# Altium schematic sheet JW302-01-V01_A0.SchDoc
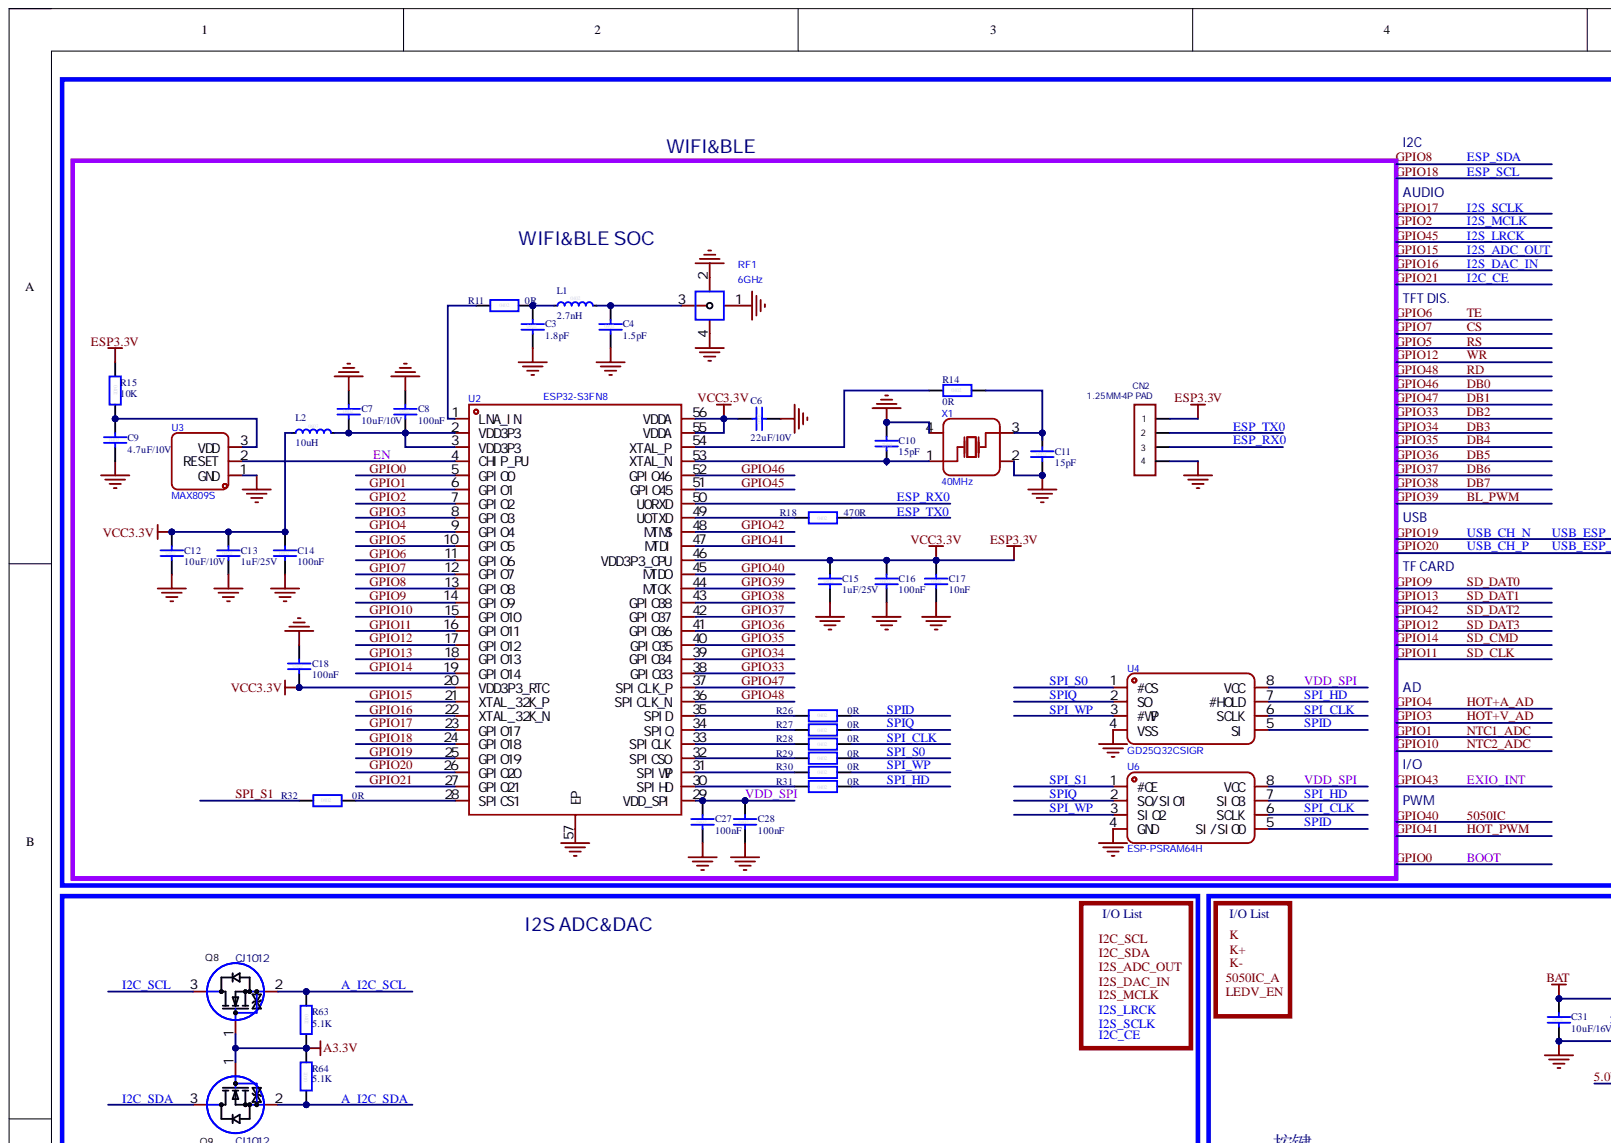
[diagram p0: JW302-01-V01_A0.SchDoc, top left]
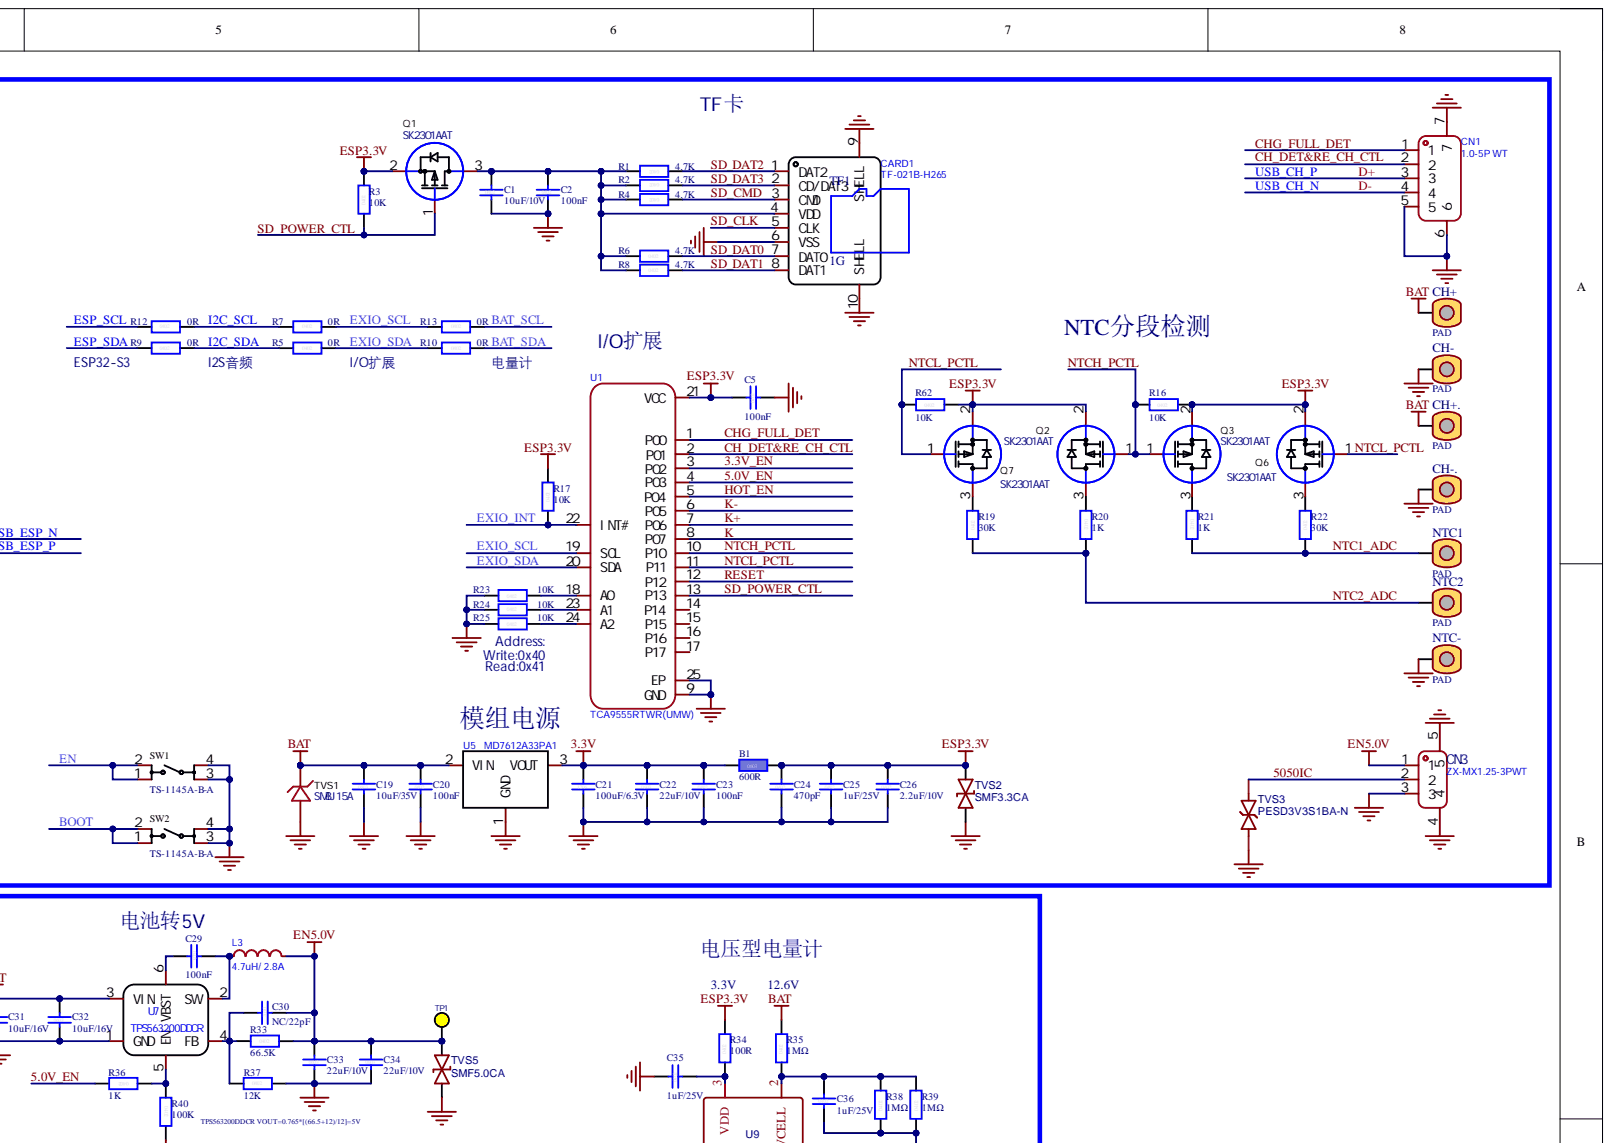
[diagram p1: JW302-01-V01_A0.SchDoc, top right]
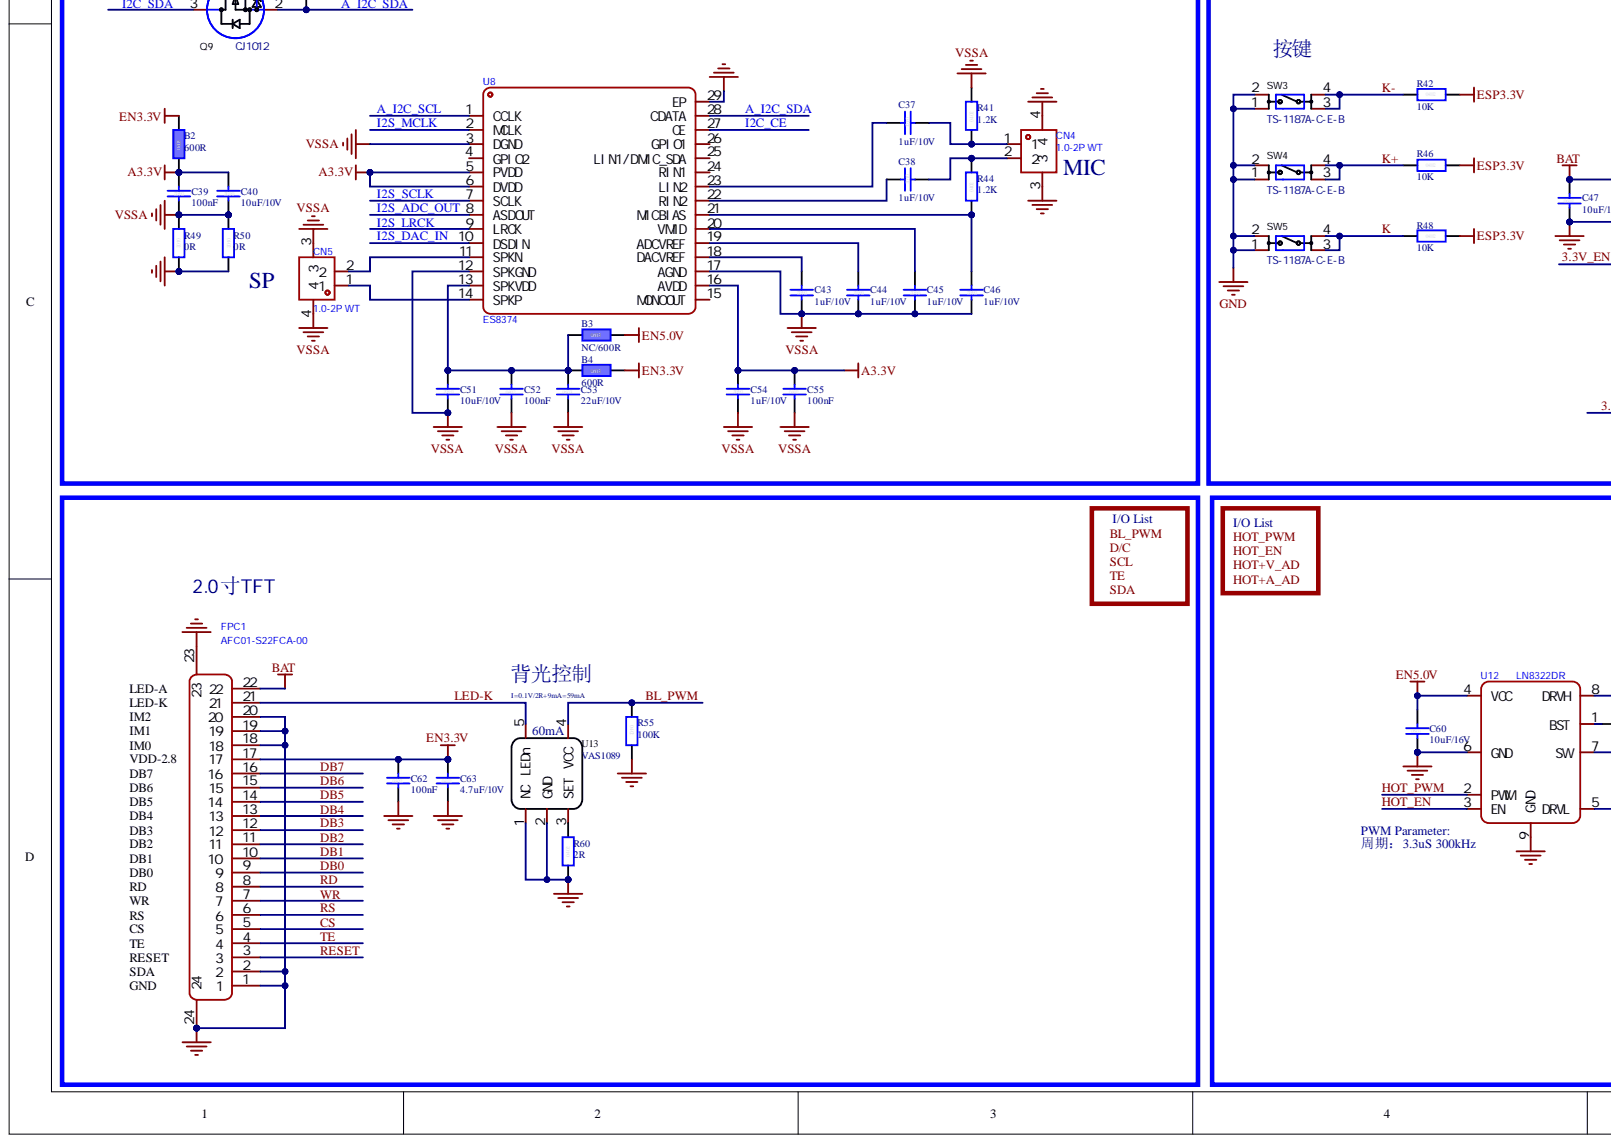
[diagram p2: JW302-01-V01_A0.SchDoc, bottom left]
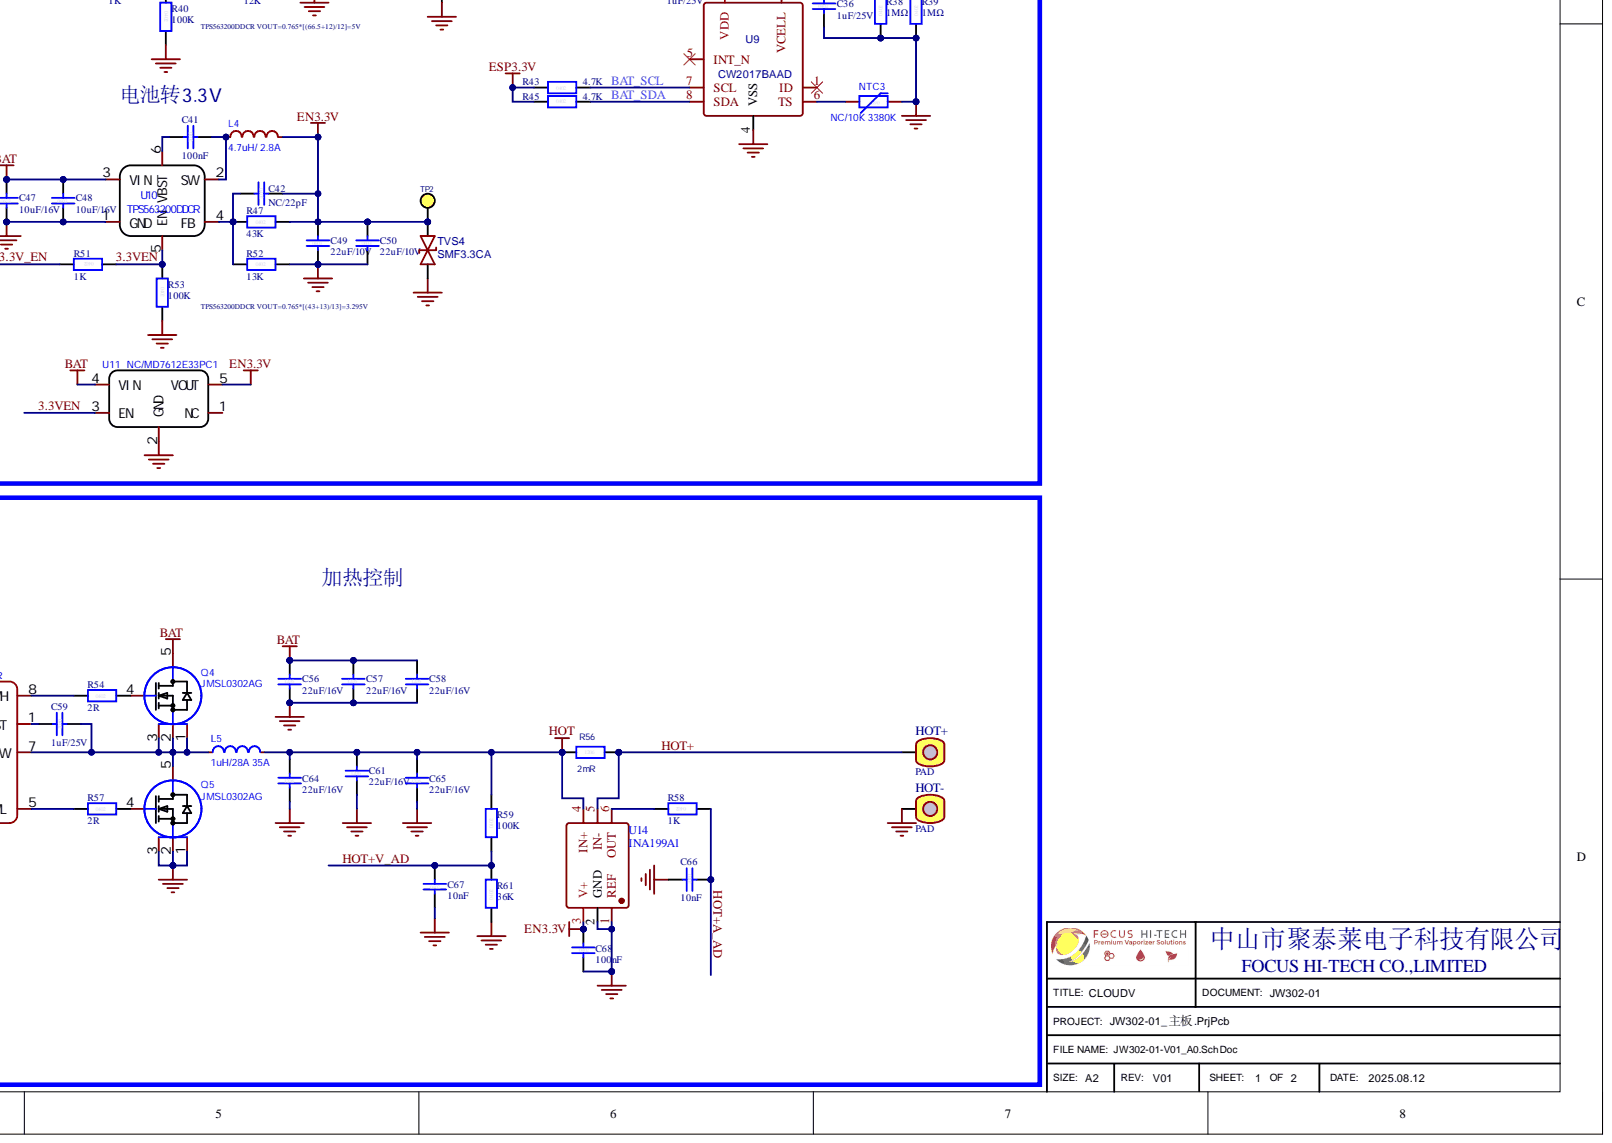
[diagram p3: JW302-01-V01_A0.SchDoc, bottom right]

## Parts
B1  600R  [R 0603_L]
B2  600R  [R 0603_L]
B3  NC/600R  [R 0603_L]
B4  600R  [R 0603_L]
C1  10uF/10V  [C 0402_L]
C2  100nF  [C 0402_L]
C3  1.8pF  [C 0402_L]
C4  1.5pF  [C 0402_L]
C5  100nF  [C 0402_L]
C6  22uF/10V  [C 0603_L]
C7  10uF/10V  [C 0402_L]
C8  100nF  [C 0402_L]
C9  4.7uF/10V  [C 0402_L]
C10  15pF  [C 0402_L]
C11  15pF  [C 0402_L]
C12  10uF/10V  [C 0402_L]
C13  1uF/25V  [C 0402_L]
C14  100nF  [C 0402_L]
C15  1uF/25V  [C 0402_L]
C16  100nF  [C 0402_L]
C17  10nF  [C 0402_L]
C18  100nF  [C 0402_L]
C19  10uF/35V  [C 0603_L]
C20  100nF  [C 0402_L]
C21  100uF/6.3V  [C 1206_L]
C22  22uF/10V  [C 0603_L]
C23  100nF  [C 0402_L]
C24  470pF  [C 0402_L]
C25  1uF/25V  [C 0402_L]
C26  2.2uF/10V  [C 0402_L]
C27  100nF  [C 0402_L]
C28  100nF  [C 0402_L]
C29  100nF  [C 0402_L]
C30  NC/22pF  [C 0402_L]
C31  10uF/16V  [C 0603_L]
C32  10uF/16V  [C 0603_L]
C33  22uF/10V  [C 0603_L]
C34  22uF/10V  [C 0603_L]
C35  1uF/25V  [C 0402_L]
C36  1uF/25V  [C 0402_L]
C37  1uF/10V  [C 0402_L]
C38  1uF/10V  [C 0402_L]
C39  100nF  [C 0402_L]
C40  10uF/10V  [C 0402_L]
C41  100nF  [C 0402_L]
C42  NC/22pF  [C 0402_L]
C43  1uF/10V  [C 0402_L]
C44  1uF/10V  [C 0402_L]
C45  1uF/10V  [C 0402_L]
C46  1uF/10V  [C 0402_L]
C47  10uF/16V  [C 0603_L]
C48  10uF/16V  [C 0603_L]
C49  22uF/10V  [C 0603_L]
C50  22uF/10V  [C 0603_L]
C51  10uF/10V  [C 0402_L]
C52  100nF  [C 0402_L]
C53  22uF/10V  [C 0603_L]
C54  1uF/10V  [C 0402_L]
C55  100nF  [C 0402_L]
C56  22uF/16V  [C 1206_L]
C57  22uF/16V  [C 1206_L]
C58  22uF/16V  [C 1206_L]
C59  1uF/25V  [C 0402_L]
C60  10uF/16V  [C 0603_L]
C61  22uF/16V  [C 1206_L]
C62  100nF  [C 0402_L]
C63  4.7uF/10V  [C 0402_L]
C64  22uF/16V  [C 1206_L]
C65  22uF/16V  [C 1206_L]
C66  10nF  [C 0402_L]
C67  10nF  [C 0402_L]
C68  100nF  [C 0402_L]
CARD1  TF-021B-H265  [TF-SMD_TF-021B-H265]
CH+  PAD  [接线焊盘2.0*2.0/1.0mm]
CH+.  PAD  [接线焊盘2.0*2.0/1.0mm]
CH-  PAD  [接线焊盘2.0*2.0/1.0mm]
CH-.  PAD  [接线焊盘2.0*2.0/1.0mm]
CN1  1.0-5P WT  [CONN-SMD_5P-P1.00_SHOU-HAN_1.0-5P-WT]
CN2  1.25MM-4P PAD  [1.25MM-4P PAD]
CN3  ZX-MX1.25-3PWT  [CONN-SMD_3P-P1.25_ZX-MX1.25-3PWT]
CN4  1.0-2P WT  [CONN-SMD_2P-P1.00_SHOU-HAN_1.0-2P-WT]
CN5  1.0-2P WT  [CONN-SMD_2P-P1.00_SHOU-HAN_1.0-2P-WT]
FPC1  AFC01-S22FCA-00  [FPC-SMD_P0.5-22P_FGS-XJ-H2.0]
HOT+  PAD  [接线焊盘2.0*2.0/1.0mm]
HOT-  PAD  [接线焊盘2.0*2.0/1.0mm]
L1  2.7nH  [R 0402_L]
L2  10uH  [R 0603_L]
L3  4.7uH/ 2.8A  Rated Current: 2.8A  [IND-SMD_L4.4-W4.2]
L4  4.7uH/ 2.8A  Rated Current: 2.8A  [IND-SMD_L4.4-W4.2]
L5  1uH/28A 35A  Saturation Current (Isat): 35A  [IND-SMD_L12.6-W13.5]
NTC1  PAD  [接线焊盘2.0*2.0/1.0mm]
NTC2  PAD  [接线焊盘2.0*2.0/1.0mm]
NTC3  NC/10K 3380K  B Constant (25℃/50℃): 3380K  Supplier Footprint: 0603  [R0603]
NTC-  PAD  [接线焊盘2.0*2.0/1.0mm]
Q1  SK2301AAT  [SOT-523-3_L1.6-W0.8-P1.00-LS1.6-BR]
Q2  SK2301AAT  [SOT-523-3_L1.6-W0.8-P1.00-LS1.6-BR]
Q3  SK2301AAT  [SOT-523-3_L1.6-W0.8-P1.00-LS1.6-BR]
Q4  JMSL0302AG  [DFN-5_L6.1-W5.1-P1.27-BL]
Q5  JMSL0302AG  [DFN-5_L6.1-W5.1-P1.27-BL]
Q6  SK2301AAT  [SOT-523-3_L1.6-W0.8-P1.00-LS1.6-BR]
Q7  SK2301AAT  [SOT-523-3_L1.6-W0.8-P1.00-LS1.6-BR]
Q8  CJ1012  [SOT-523-3_L1.6-W0.8-P1.00-LS1.6-BR]
Q9  CJ1012  [SOT-523-3_L1.6-W0.8-P1.00-LS1.6-BR]
R1  4.7K  [R 0402_L]
R2  4.7K  [R 0402_L]
R3  10K  [R 0402_L]
R4  4.7K  [R 0402_L]
R5  0R  [R 0402_L]
R6  4.7K  [R 0402_L]
R7  0R  [R 0402_L]
R8  4.7K  [R 0402_L]
R9  0R  [R 0402_L]
R10  0R  [R 0402_L]
R11  0R  [R 0402_L]
R12  0R  [R 0402_L]
R13  0R  [R 0402_L]
R14  0R  [R 0402_L]
R15  10K  [R 0402_L]
R16  10K  [R 0402_L]
R17  10K  [R 0402_L]
R18  470R  [R 0402_L]
R19  30K  [R 0402_L]
R20  1K  [R 0402_L]
R21  1K  [R 0402_L]
R22  30K  [R 0402_L]
R23  10K  [R 0402_L]
R24  10K  [R 0402_L]
R25  10K  [R 0402_L]
R26  0R  [R 0402_L]
R27  0R  [R 0402_L]
R28  0R  [R 0402_L]
R29  0R  [R 0402_L]
R30  0R  [R 0402_L]
R31  0R  [R 0402_L]
R32  0R  [R 0402_L]
R33  66.5K  [R 0402_L]
R34  100R  [R 0402_L]
R35  1MΩ  [R 0402_L]
R36  1K  [R 0402_L]
R37  12K  [R 0402_L]
R38  1MΩ  [R 0402_L]
R39  1MΩ  [R 0402_L]
R40  100K  [R 0402_L]
R41  1.2K  [R 0402_L]
R42  10K  [R 0402_L]
R43  4.7K  [R 0402_L]
R44  1.2K  [R 0402_L]
R45  4.7K  [R 0402_L]
R46  10K  [R 0402_L]
R47  43K  [R 0402_L]
R48  10K  [R 0402_L]
R49  0R  [R 0402_L]
R50  0R  [R 0402_L]
R51  1K  [R 0402_L]
R52  13K  [R 0402_L]
R53  100K  [R 0402_L]
R54  2R  [R 0402_L]
R55  100K  [R 0402_L]
R56  2mR  [R 1206_L]
R57  2R  [R 0402_L]
R58  1K  [R 0402_L]
R59  100K  [R 0402_L]
R60  2R  [R 0402_L]
R61  36K  [R 0402_L]
R62  10K  [R 0402_L]
R63  5.1K  [R 0402_L]
R64  5.1K  [R 0402_L]
RF1  6GHz  [IPEX-SMD_4P-L1.7-W1.7-LS2.0-L-4]
SW1  TS-1145A-B-A  [SW-SMD_4P-L4.2-W3.2-P2.15-LS5.2]
SW2  TS-1145A-B-A  [SW-SMD_4P-L4.2-W3.2-P2.15-LS5.2]
SW3  TS-1187A-C-E-B  [SW-SMD_4P-L5.1-W5.1-P3.70-LS6.5-TL-2]
SW4  TS-1187A-C-E-B  [SW-SMD_4P-L5.1-W5.1-P3.70-LS6.5-TL-2]
SW5  TS-1187A-C-E-B  [SW-SMD_4P-L5.1-W5.1-P3.70-LS6.5-TL-2]
TF1  1G  [TFCard]
TP1  TP  [TP]
TP2  TP  [TP]
TVS1  SMBJ15A  [SMB_L4.6-W3.6-LS5.3-RD]
TVS2  SMF3.3CA  [SOD-123_L2.8-W1.8-LS3.7-BI]
TVS3  PESD3V3S1BA-N  [SOD-523_L1.2-W0.8-LS1.6-BI]
TVS4  SMF3.3CA  [SOD-123_L2.8-W1.8-LS3.7-BI]
TVS5  SMF5.0CA  [SOD-123_L2.8-W1.8-LS3.7-BI]
U1  TCA9555RTWR(UMW)  [HVQFN-24_L4.0-W4.0-P0.50-BL-EP2.6]
U2  ESP32-S3FN8  [QFN-56_L7.0-W7.0-P0.40-TL-EP4.0]
U3  MAX809S  [SOT-23-3_L2.9-W1.3-P1.90-LS2.4-BR]
U4  GD25Q32CSIGR  [SOIC-8_L5.3-W5.3-P1.27-LS8.0-BL]
U5  MD7612A33PA1  [SOT-89-3_L4.5-W2.5-P1.50-LS4.2-BR]
U6  ESP-PSRAM64H  [SOP-8_L4.9-W3.9-P1.27-LS6.0-BL]
U7  TPS563200DDCR  [SOT-23-6_L2.9-W1.6-P0.95-LS2.8-BR]
U8  ES8374  [QFN-28_L4.0-W4.0-P0.45-BL-EP]
U9  CW2017BAAD  [WDFN-8_L2.0-W2.0-P0.50-BL]
U10  TPS563200DDCR  [SOT-23-6_L2.9-W1.6-P0.95-LS2.8-BR]
U11  NC/MD7612E33PC1  [SOT-89-5_L4.5-W2.5-P1.50-LS4.5-BR]
U12  LN8322DR  [WDFN-8_L2.0-W2.0-P0.50-BL-EP]
U13  VAS1089  [SOT23-5L]
U14  INA199A1  [SC-70-6_L2.2-W1.3-P0.65-LS2.1-BR]
X1  40MHz  External load capacitor: 15pF  [CRYSTAL-SMD_4P-L3.2-W2.5-BL-1]

## Nets
3.3V: B1.1 C21.2 C22.2 C23.2 U5.3
A3.3V: B2.1 C39.1 C40.1 C54.1 C55.2 Q8.1 Q9.1 R63.2 R64.2 U8.5(PVDD) U8.6(DVDD) U8.16(AVDD)
BAT: C19.2 C20.2 C31.2 C32.2 C47.2 C48.2 C56.2 C57.2 C58.2 CH+.1 CH+..1 FPC1.22 Q4.5 R35.2 TVS1.1 U5.2 U7.3(VIN) U10.3(VIN) U11.4(VIN)
EN3.3V: B2.2 B4.2 C42.2 C49.2 C50.2 C62.2 C63.2 C68.2 FPC1.17 L4.2 R47.2 TP2.1 TVS4.2 U11.5(VOUT) U14.3(V+)
EN5.0V: B3.2 C30.2 C33.2 C34.2 C60.2 CN3.1 L3.2 R33.2 TP1.1 TVS5.2 U12.4(VCC)
ESP3.3V: B1.2 C5.2 C6.2 C12.2 C13.2 C14.2 C15.2 C16.2 C17.2 C18.1 C24.2 C25.2 C26.2 CN2.1 L2.1 Q1.2 Q2.2 Q3.2 Q6.2 Q7.2 R3.2 R15.1 R16.2 R17.2 R34.2 R42.2 R43.1 R45.1 R46.2 R48.2 R62.2 TVS2.2 U1.21(VCC) U2.20(VDD3P3_RTC) U2.46(VDD3P3_CPU) U2.55(VDDA) U2.56(VDDA)
GND: C1.1 C2.1 C3.1 C4.1 C5.1 C6.1 C7.2 C8.2 C9.1 C10.1 C11.1 C12.1 C13.1 C14.1 C15.1 C16.1 C17.1 C18.2 C19.1 C20.1 C21.1 C22.1 C23.1 C24.1 C25.1 C26.1 C27.1 C28.1 C31.1 C32.1 C33.1 C34.1 C35.2 C36.1 C47.1 C48.1 C49.1 C50.1 C56.1 C57.1 C58.1 C60.1 C61.1 C62.1 C63.1 C64.1 C65.1 C66.1 C67.1 C68.1 CARD1.6(VSS) CARD1.9(SHELL) CARD1.10(SHELL) CH-.1 CH-..1 CN1.5 CN1.6 CN1.7 CN2.4 CN3.3 CN3.4 CN3.5 CN4.3 CN4.4 FPC1.1 FPC1.2 FPC1.18 FPC1.19 FPC1.20 FPC1.23 FPC1.24 HOT-.1 NTC3.1 NTC-.1 Q5.1 Q5.2 Q5.3 R23.1 R24.1 R25.1 R37.2 R38.1 R39.1 R40.2 R49.2 R50.2 R52.2 R53.2 R55.2 R60.1 R61.1 RF1.1 RF1.2 RF1.4 SW1.3 SW1.4 SW2.3 SW2.4 SW3.1 SW3.2 SW4.1 SW4.2 SW5.1 SW5.2 TVS1.2 TVS2.1 TVS3.1 TVS4.1 TVS5.1 U1.9(GND) U1.25(EP) U2.57(EP) U3.1 U4.4(VSS) U5.1 U6.4(GND) U7.1(GND) U8.29(EP) U9.4(VSS) U10.1(GND) U11.2(GND) U12.6(GND) U12.9(GND) U13.1(NC) U13.2(GND) U14.1(REF) U14.2(GND) X1.2 X1.4
HOT: C61.2 C64.2 C65.2 L5.2 R56.1 R59.2 U14.4(IN+)
VSSA: C39.2 C40.2 C43.2 C44.2 C45.2 C46.2 C51.2 C52.1 C53.1 C54.2 C55.1 CN5.3 CN5.4 R41.2 R49.1 R50.1 U8.3(DGND) U8.12(SPKGND) U8.17(AGND)
3.3V_EN: R51.2 U1.3(P02)
3.3VEN: R51.1 R53.1 U10.5(EN) U11.3(EN)
5.0V_EN: R36.2 U1.4(P03)
5050IC: CN3.2 TVS3.2 U2.45(MTDO)
A_I2C_SCL: Q8.2 R63.1 U8.1(CCLK)
A_I2C_SDA: Q9.2 R64.1 U8.28(CDATA)
BAT_SCL: R13.1 R43.2 U9.7(SCL)
BAT_SDA: R10.1 R45.2 U9.8(SDA)
BL_PWM: R55.1 U2.44(MTCK) U13.4(VCC)
BOOT: SW2.1 SW2.2 U2.5(GPIO0)
CH_DET&RE_CH_CTL: CN1.2 U1.2(P01)
CHG_FULL_DET: CN1.1 U1.1(P00)
CS: FPC1.5 U2.12(GPIO7)
D+: CN1.3 U2.26(GPIO20)
D-: CN1.4 U2.25(GPIO19)
DB0: FPC1.9 U2.52(GPIO46)
DB1: FPC1.10 U2.37(SPICLK_P)
DB2: FPC1.11 U2.38(GPIO33)
DB3: FPC1.12 U2.39(GPIO34)
DB4: FPC1.13 U2.40(GPIO35)
DB5: FPC1.14 U2.41(GPIO36)
DB6: FPC1.15 U2.42(GPIO37)
DB7: FPC1.16 U2.43(GPIO38)
EN: SW1.1 SW1.2 U2.4(CHIP_PU) U3.2
ESP_RX0: CN2.3 U2.50(U0RXD)
ESP_SCL: R12.1 U2.24(GPIO18)
ESP_SDA: R9.1 U2.13(GPIO8)
ESP_TX0: CN2.2 R18.2
EXIO_INT: R17.1 U1.22(INT#)
EXIO_SCL: R7.1 R13.2 U1.19(SCL)
EXIO_SDA: R5.1 R10.2 U1.20(SDA)
GPIO1: NTC1.1 R21.2 R22.1 U2.6(GPIO1)
GPIO2: U2.7(GPIO2) U8.2(MCLK)
GPIO3: C67.2 R59.1 R61.2 U2.8(GPIO3)
GPIO4: C66.2 R58.2 U2.9(GPIO4)
GPIO5: FPC1.6 U2.10(GPIO5)
GPIO6: FPC1.4 U2.11(GPIO6)
GPIO9: CARD1.7(DAT0) R6.2 U2.14(GPIO9)
GPIO10: NTC2.1 R19.1 R20.2 U2.15(GPIO10)
GPIO11: CARD1.5(CLK) U2.16(GPIO11)
GPIO12: CARD1.2(CD/DAT3) FPC1.7 R2.1 U2.17(GPIO12)
GPIO13: CARD1.8(DAT1) R8.2 U2.18(GPIO13)
GPIO14: CARD1.3(CMD) R4.1 U2.19(GPIO14)
GPIO15: U2.21(XTAL_32K_P) U8.8(ASDOUT)
GPIO16: U2.22(XTAL_32K_N) U8.10(DSDIN)
GPIO17: U2.23(GPIO17) U8.7(SCLK)
GPIO21: U2.27(GPIO21) U8.27(CE)
GPIO41: U2.47(MTDI) U12.2(PWM)
GPIO42: CARD1.1(DAT2) R1.1 U2.48(MTMS)
GPIO45: U2.51(GPIO45) U8.9(LRCK)
GPIO48: FPC1.8 U2.36(SPICLK_N)
HOT+: HOT+.1 R56.2 U14.5(IN-)
HOT_EN: U1.5(P04) U12.3(EN)
I2C_SCL: Q8.3 R7.2 R12.2
I2C_SDA: Q9.3 R5.2 R9.2
K: R48.1 SW5.3 SW5.4 U1.8(P07)
K+: R46.1 SW4.3 SW4.4 U1.7(P06)
K-: R42.1 SW3.3 SW3.4 U1.6(P05)
LED-K: FPC1.21 U13.5(LEDn)
NTCH_PCTL: Q2.1 Q3.1 R16.1 U1.10(P10)
NTCL_PCTL: Q6.1 Q7.1 R62.1 U1.11(P11)
RESET: FPC1.3 U1.12(P12)
SD_POWER_CTL: Q1.1 R3.1 U1.13(P13)
SPI_CLK: R28.2 U4.6(SCLK) U6.6(SCLK)
SPI_HD: R31.2 U4.7(#HOLD) U6.7(SIO3)
SPI_S0: R29.2 U4.1(#CS)
SPI_S1: R32.1 U6.1(#CE)
SPI_WP: R30.2 U4.3(#WP) U6.3(SIO2)
SPID: R26.2 U4.5(SI) U6.5(SI/SIO0)
SPIQ: R27.2 U4.2(SO) U6.2(SO/SIO1)
VDD_SPI: C27.2 C28.2 U2.29(VDD_SPI) U4.8(VCC) U6.8(VCC)
NetB3_1: B3.1 B4.1 C51.1 C52.2 C53.2 U8.13(SPKVDD)
NetC1_2: C1.2 C2.2 CARD1.4(VDD) Q1.3 R1.2 R2.2 R4.2 R6.1 R8.1
NetC3_2: C3.2 L1.1 R11.1
NetC4_2: C4.2 L1.2 RF1.3
NetC7_1: C7.1 C8.1 L2.2 U2.2(VDD3P3) U2.3(VDD3P3)
NetC9_2: C9.2 R15.2 U3.3
NetC10_2: C10.2 U2.53(XTAL_N) X1.1
NetC11_2: C11.2 R14.2 X1.3
NetC29_1: C29.1 U7.6(VBST)
NetC29_2: C29.2 L3.1 U7.2(SW)
NetC30_1: C30.1 R33.1 R37.1 U7.4(FB)
NetC35_1: C35.1 R34.1 U9.3(VDD)
NetC36_2: C36.2 R35.1 R38.2 R39.2 U9.2(VCELL)
NetC37_1: C37.1 U8.23(LIN2)
NetC37_2: C37.2 CN4.1 R41.1
NetC38_1: C38.1 U8.22(RIN2)
NetC38_2: C38.2 CN4.2 R44.2
NetC41_1: C41.1 U10.6(VBST)
NetC41_2: C41.2 L4.1 U10.2(SW)
NetC42_1: C42.1 R47.1 R52.1 U10.4(FB)
NetC43_1: C43.1 U8.18(DACVREF)
NetC44_1: C44.1 U8.19(ADCVREF)
NetC45_1: C45.1 U8.20(VMID)
NetC46_1: C46.1 R44.1 U8.21(MICBIAS)
NetC59_1: C59.1 U12.1(BST)
NetC59_2: C59.2 L5.1 Q4.1 Q4.2 Q4.3 Q5.5 U12.7(SW)
NetCN5_1: CN5.1 U8.14(SPKP)
NetCN5_2: CN5.2 U8.11(SPKN)
NetNTC3_2: NTC3.2 U9.6(TS)
NetQ2_3: Q2.3 R20.1
NetQ3_3: Q3.3 R21.1
NetQ4_4: Q4.4 R54.2
NetQ5_4: Q5.4 R57.2
NetQ6_3: Q6.3 R22.2
NetQ7_3: Q7.3 R19.2
NetR11_2: R11.2 U2.1(LNA_IN)
NetR14_1: R14.1 U2.54(XTAL_P)
NetR18_1: R18.1 U2.49(U0TXD)
NetR23_2: R23.2 U1.18(A0)
NetR24_2: R24.2 U1.23(A1)
NetR25_2: R25.2 U1.24(A2)
NetR26_1: R26.1 U2.35(SPID)
NetR27_1: R27.1 U2.34(SPIQ)
NetR28_1: R28.1 U2.33(SPICLK)
NetR29_1: R29.1 U2.32(SPICS0)
NetR30_1: R30.1 U2.31(SPIWP)
NetR31_1: R31.1 U2.30(SPIHD)
NetR32_2: R32.2 U2.28(SPICS1)
NetR36_1: R36.1 R40.1 U7.5(EN)
NetR54_1: R54.1 U12.8(DRVH)
NetR57_1: R57.1 U12.5(DRVL)
NetR58_1: R58.1 U14.6(OUT)
NetR60_2: R60.2 U13.3(SET)
5050IC_A:
D/C:
LEDV_EN:
SCL:
SDA:

Not connected: U1 14(P14) 15(P15) 16(P16) 17(P17); U8 4(GPIO2) 15(MONOOUT) 24(RIN1) 25(LIN1/DMIC_SDA) 26(GPIO1); U9 1(ID) 5(INT_N); U11 1(NC)
